# Altium schematic sheet [02] Power.SchDoc
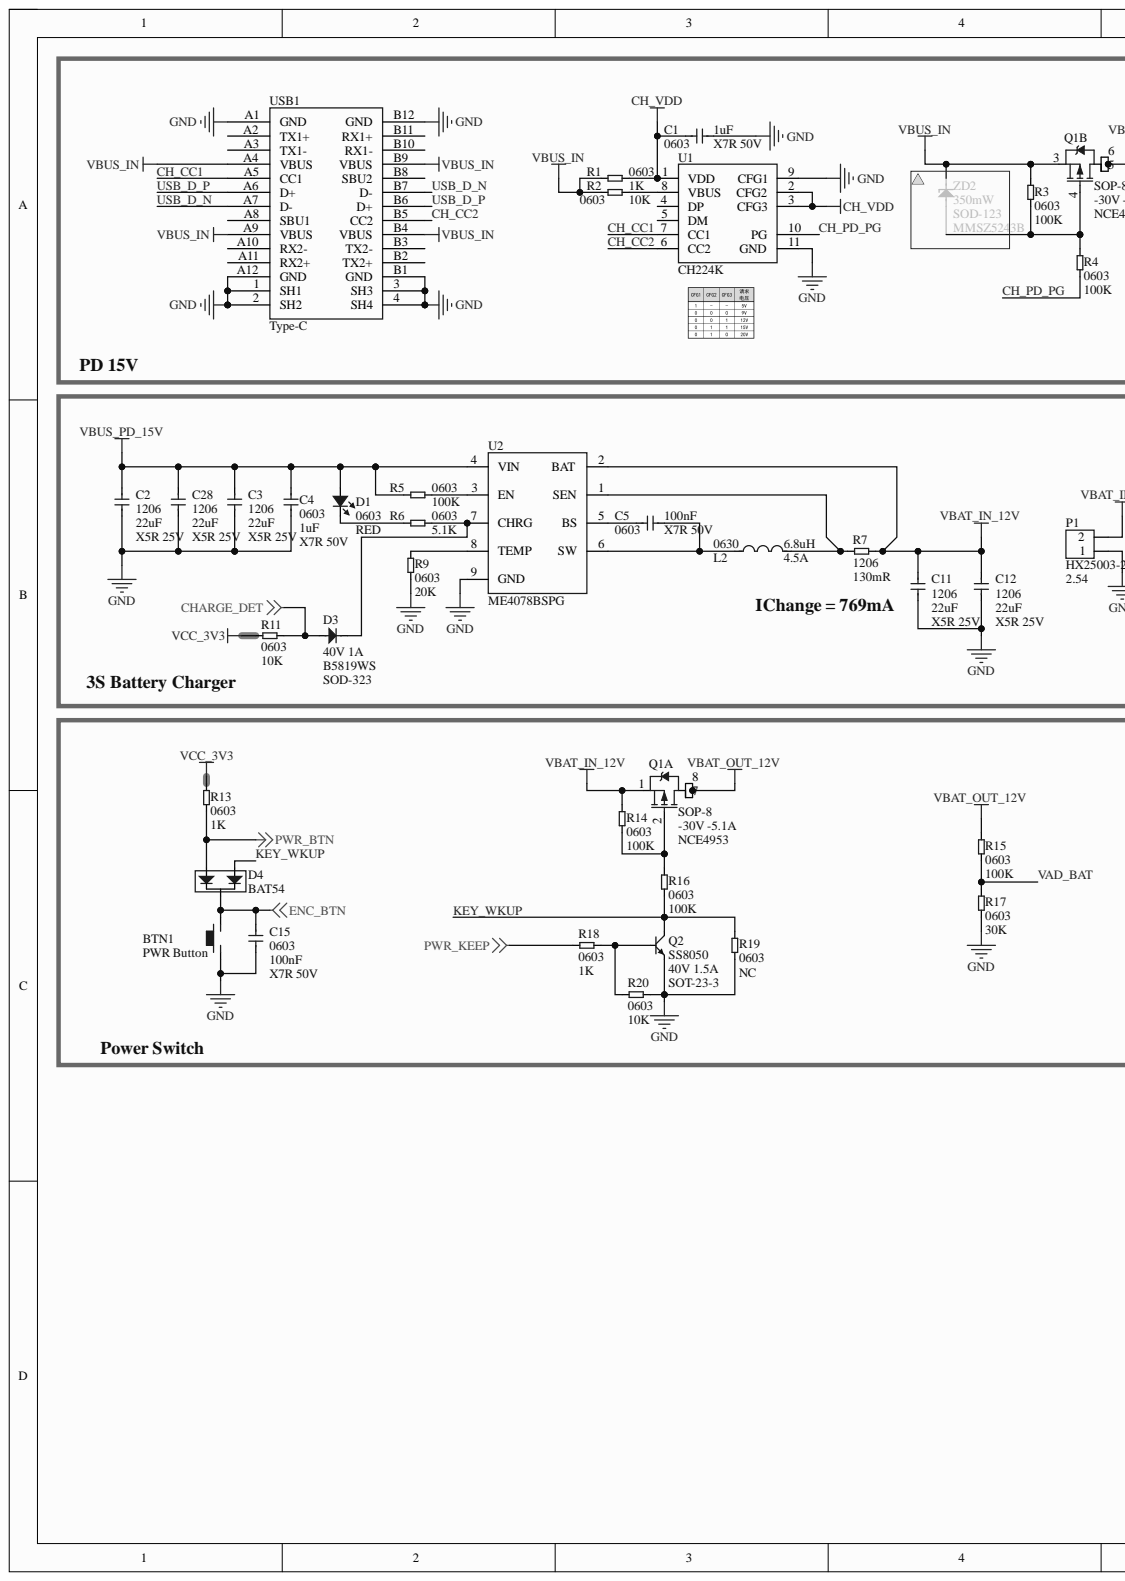
[diagram p0: [02] Power.SchDoc, left]
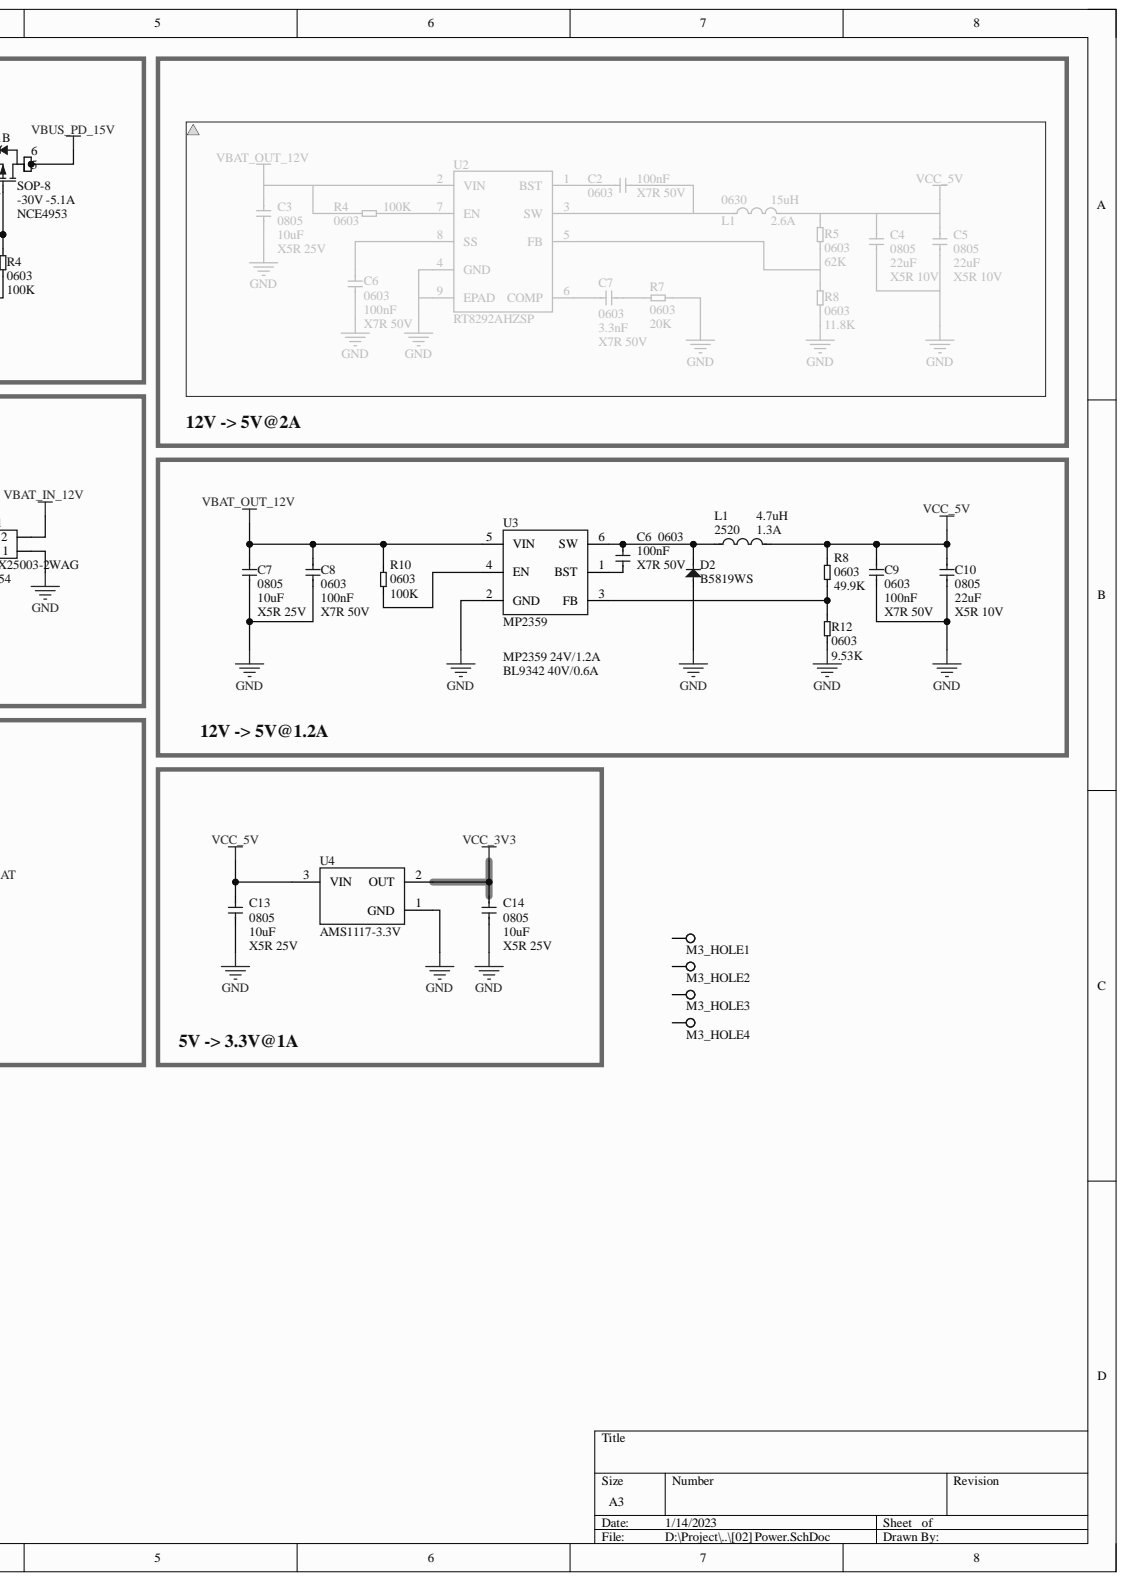
[diagram p1: [02] Power.SchDoc, right]

## Parts
BTN1  PWR Button  [BTN-4P-4.2*3.4*2.5]
C1  1uF  [0603]
C2  22uF  [1206]
C3  22uF  Specification: X5R 25V  [1206]
C4  1uF  [0603]
C5  100nF  [0603]
C6  100nF  [0603]
C7  10uF  [0805]
C8  100nF  [0603]
C9  100nF  [0603]
C10  22uF  Specification: X5R 10V  [0805]
C11  22uF  [1206]
C12  22uF  Specification: X5R 25V  [1206]
C13  10uF  [0805]
C14  10uF  Specification: X5R 25V  [0805]
C15  100nF  Specification: X7R 50V  [0603]
C28  22uF  Specification: X5R 25V  [1206]
D1  RED  [0603]
D2  B5819WS  [SOD-323]
D3  B5819WS  Specification: 40V 1A  [SOD-323]
D4  BAT54  [SOT-23-3]
L1  4.7uH  Specification: 1.3A  [2520]
L2  6.8uH  Specification: 4.5A  [0630]
M3_HOLE1  TestPoint  [Hole_M3_PAD]  (value not drawn)
M3_HOLE2  TestPoint  [Hole_M3_PAD]  (value not drawn)
M3_HOLE3  TestPoint  [Hole_M3_PAD]  (value not drawn)
M3_HOLE4  TestPoint  [Hole_M3_PAD]  (value not drawn)
P1  HX25003-2WAG  Type: 2.54  [XH_2-SLEEP]
Q1  NCE4953  Specification: -30V -5.1A  [SOP-8]  (parts A,B)
Q2  SS8050  [SOT-23-3]
R1  1K  [0603]
R2  10K  [0603]
R3  100K  [0603]
R4  100K  [0603]
R5  100K  [0603]
R6  5.1K  [0603]
R7  130mR  [1206]
R8  49.9K  [0603]
R9  20K  [0603]
R10  100K  [0603]
R11  10K  [0603]
R12  9.53K  [0603]
R13  1K  [0603]
R14  100K  [0603]
R15  100K  [0603]
R16  100K  [0603]
R17  30K  [0603]
R18  1K  [0603]
R19  NC  [0603]
R20  10K  [0603]
U1  CH224K  [HSOP-10-P1.0]
U2  ME4078BSPG  [HSOP-8-P1.27]
U3  MP2359  [SOT-23-6]
U4  AMS1117-3.3V  [SOT-223-3]
USB1  Type-C  [USB-Type-C]

## Nets
CH_VDD: C1.1 R1.1 U1.1(VDD) U1.2(CFG2) U1.3(CFG3)
CHARGE_DET: D3.1 R11.2
ENC_BTN: BTN1.2 C15.1 D4.3
GND: BTN1.1 C1.2 C2.1 C3.1 C4.2 C7.2 C8.2 C9.2 C10.2 C11.1 C12.1 C13.1 C14.1 C15.2 C28.1 D2.1 P1.1 Q2.2 R9.2 R12.2 R17.1 R19.2 R20.1 U1.9(CFG1) U1.11(GND) U2.9(GND) U3.2(GND) U4.1 USB1.1(SH1) USB1.2(SH2) USB1.3(SH3) USB1.4(SH4) USB1.A1(GND) USB1.A12(GND) USB1.B1(GND) USB1.B12(GND)
PWR_BTN: D4.1 R13.1
PWR_KEEP: R18.1
VBAT_IN_12V: C11.2 C12.2 P1.2 Q1.1 R7.1 R14.2 U2.2(BAT)
VBAT_OUT_12V: C7.1 C8.1 Q1.7 Q1.8 R10.1 R15.2 U3.5(VIN)
VBUS_IN: Q1.3 R1.2 R2.2 R3.2 USB1.A4(VBUS) USB1.A9(VBUS) USB1.B4(VBUS) USB1.B9(VBUS)
VBUS_PD_15V: C2.2 C3.2 C4.1 C28.2 D1.1 Q1.5 Q1.6 R5.2 U2.4(VIN)
VCC_3V3: C14.2 R11.1 R13.2 U4.2
VCC_5V: C9.1 C10.1 C13.2 L1.2 R8.1 U4.3
CH_CC1: U1.7(CC1) USB1.A5(CC1)
CH_CC2: U1.6(CC2) USB1.B5(CC2)
CH_PD_PG: R4.1 U1.10(PG)
KEY_WKUP: D4.2 Q2.3 R16.1 R19.1
USB_D_N: USB1.A7(D-) USB1.B7(D-)
USB_D_P: USB1.A6(D+) USB1.B6(D+)
VAD_BAT: R15.1 R17.2
NetC5_1: C5.1 L2.1 U2.6(SW)
NetC5_2: C5.2 U2.5(BS)
NetC6_1: C6.1 D2.2 L1.1 U3.6(SW)
NetC6_2: C6.2 U3.1(BST)
NetD1_2: D1.2 R6.2
NetD3_2: D3.2 R6.1 U2.7(CHRG)
NetL2_2: L2.2 R7.2 U2.1(SEN)
NetQ1_2: Q1.2 R14.1 R16.2
NetQ1_4: Q1.4 R3.1 R4.2
NetQ2_1: Q2.1 R18.2 R20.2
NetR2_1: R2.1 U1.8(VBUS)
NetR5_1: R5.1 U2.3(EN)
NetR8_2: R8.2 R12.1 U3.3(FB)
NetR9_1: R9.1 U2.8(TEMP)
NetR10_2: R10.2 U3.4(EN)

Not connected: M3_HOLE1 1; M3_HOLE2 1; M3_HOLE3 1; M3_HOLE4 1; U1 4(DP) 5(DM); USB1 A2(TX1+) A3(TX1-) A8(SBU1) A10(RX2-) A11(RX2+) B2(TX2+) B3(TX2-) B8(SBU2) B10(RX1-) B11(RX1+)

## Library data of the parts
BTN1 = Button: Component Kind: Standard; Description: Button; Library Name: SCH_General.SchLib
C1 C4-C6 C8 C9 = CAP: Capacitor; Specification: X7R 50V; Component Kind: Standard; Library Name: SCH_General.SchLib
  C1 C4: Value: 1uF
  C5 C6 C8 C9: Value: 100nF
C2 C7 C11 C13 = CAP: Capacitor; Specification: X5R 25V; Component Kind: Standard; Library Name: SCH_General.SchLib
  C2 C11: Value: 22uF
  C7 C13: Value: 10uF
C3 C10 C12 C14 C15 C28 = CAP: Capacitor; Component Kind: Standard; Library Name: SCH_General.SchLib
  C3 C10 C12 C28: Value: 22uF
  C14: Value: 10uF
  C15: Value: 100nF
D1 = LED: Component Kind: Standard; Description: LED; Library Name: SCH_General.SchLib; Value: RED
D2 = Diode: Specification: 40V 1A; Component Kind: Standard; Description: Diode; Library Name: SCH_General.SchLib; Value: B5819WS
D3 = Diode: Component Kind: Standard; Description: Diode; Library Name: SCH_General.SchLib; Value: B5819WS
D4 = Diode-2: Component Kind: Standard; Library Name: SCH_General.SchLib; Value: BAT54
L1 L2 = IND: Inductor; Component Kind: Standard; Library Name: SCH_General.SchLib
  L1: Value: 4.7uH
  L2: Value: 6.8uH
P1 = HDR1x2: Header; Component Kind: Standard; Library Name: SCH_ConnectorHDR.SchLib; Value: HX25003-2WAG
Q1 = Dual_MOS-P: Dual P-Channel MOSFET; Component Kind: Standard; Library Name: SCH_General.SchLib; Value: NCE4953
Q2 = BJT_NPN: Transistor; Specification: 40V 1.5A; Component Kind: Standard; Library Name: SCH_General.SchLib; Value: SS8050
R1-R20 = RES: Resistor; Specification: ±1%; Component Kind: Standard; Library Name: SCH_General.SchLib
  R1 R13 R18: Value: 1K
  R2 R11 R20: Value: 10K
  R3-R5 R10 R14-R16: Value: 100K
  R6: Value: 5.1K
  R7: Value: 130mR
  R8: Value: 49.9K
  R9: Value: 20K
  R12: Value: 9.53K
  R17: Value: 30K
  R19: Value: NC
U1 = CH224K: USB PD 等多快充协议受电芯片; Component Kind: Standard; Library Name: SCH_Power.SchLib
U2 = ME4078: 双节、三节开关型锂电池管理; Component Kind: Standard; Library Name: SCH_Power.SchLib
U3 = MP2456: Component Kind: Standard; Library Name: SCH_Power.SchLib
U4 = LM1117-3.3V: Component Kind: Standard; Library Name: SCH_Power.SchLib
USB1 = USB-Type-C: Component Kind: Standard; Library Name: SCH_Connector.SchLib
M3_HOLE1-M3_HOLE4 = TestPoint: Component Kind: Standard; Description: TestPoint; Library Name: SCH_General.SchLib
